# Altium schematic sheet [2] - Header and I2C.SchDoc
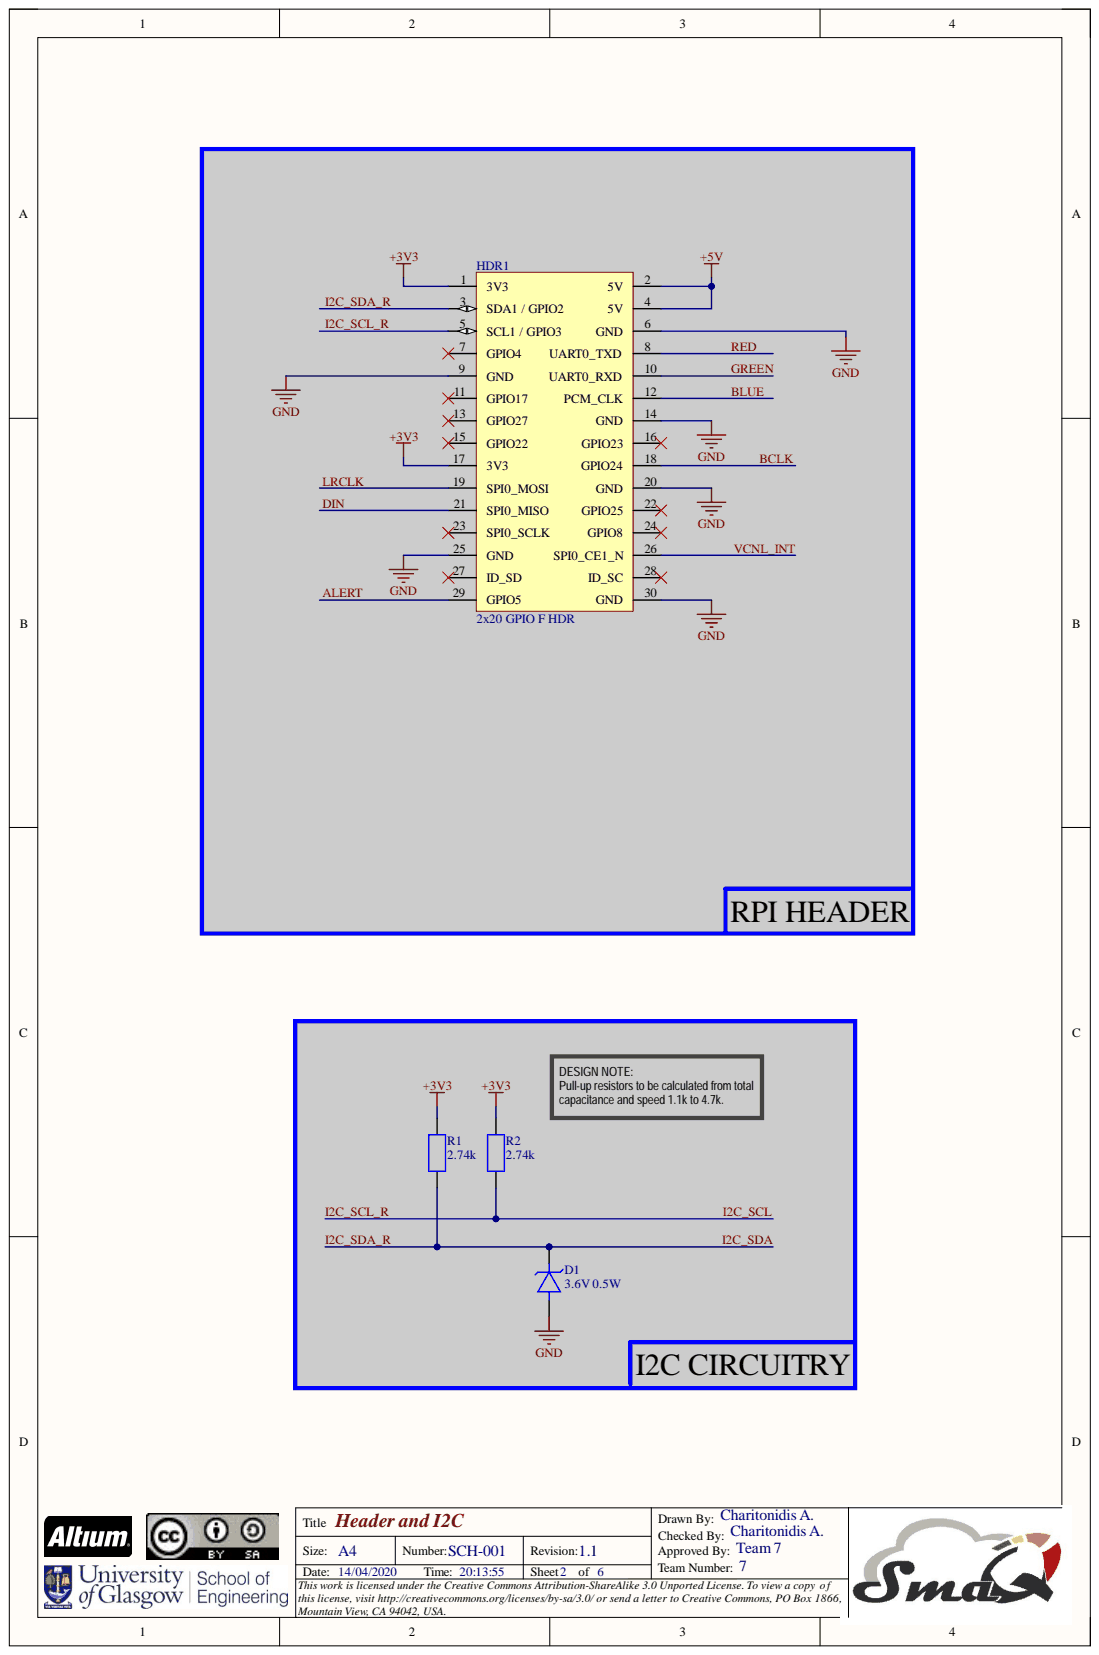
[diagram p0: [2] - Header and I2C.SchDoc]

## Parts
D1  3.6V 0.5W  Zener Voltage: 3.6V  [SOD-123]  (no BOM)
HDR1  2x20 GPIO F HDR  [2x20_Pi_Header]  (no BOM)
R1  2.74k  [R0805 (2012M)]  (no BOM)
R2  2.74k  [R0805 (2012M)]  (no BOM)

## Nets
+3V3: HDR1.1(3V3) HDR1.17(3V3) R1.2 R2.2
+5V: HDR1.2(5V) HDR1.4(5V)
GND: D1.1 HDR1.6(GND) HDR1.9(GND) HDR1.14(GND) HDR1.20(GND) HDR1.25(GND) HDR1.30(GND)
BCLK: HDR1.18(GPIO24)
BLUE: HDR1.12(PCM_CLK)
DIN: HDR1.21(SPI0_MISO)
GREEN: HDR1.10(UART0_RXD)
I2C_SCL: HDR1.5(SCL1_/_GPIO3) R2.1
I2C_SDA: D1.2 HDR1.3(SDA1_/_GPIO2) R1.1
LRCLK: HDR1.19(SPI0_MOSI)
RED: HDR1.8(UART0_TXD)
ALERT:
VCNL_INT:

Not connected: HDR1 7(GPIO4) 11(GPIO17) 13(GPIO27) 15(GPIO22) 16(GPIO23) 22(GPIO25) 23(SPI0_SCLK) 24(GPIO8) 26(SPI0_CE1_N) 27(ID_SD) 28(ID_SC) 29(GPIO5)

## Library data of the parts
D1 = BZT52B3V6-E3-08: Diode Zener Single 3.6V 2% 500mW 2-Pin SOD-123 T/R; Manufacturer 1: Vishay; Category 1: Zener Diodes; Impedance: 80R; Max Power Dissipation: 410mW; Max Reverse Leakage Current: 100nA; Zener Current: 100mA; Case/Package: SOD-123-2; ComponentLink1Description: https://datasheet.ciiva.com/24095/bzt52-106265-24095710.pdf?src-supplier=Mouser; ComponentLink1URL: https://datasheet.ciiva.com/24095/bzt52-106265-24095710.pdf?src-supplier=Mouser; Description 1: Diode Zener Single 3.6V 2% 500mW 2-Pin SOD-123 T/R; Element Configuration: Single; Manufacturer Part Number 1: BZT52B3V6-E3-08; Max Operating Temperature: 150°C; Min Operating Temperature: -55°C; Mount: Surface Mount; Number of Pins: 2; Package Quantity: 3000; Packaging: Tape and Reel; Power Dissipation: 500mW; RoHS: Yes; Stock 1: 29413; Supplier 1: Mouser; Supplier Part Number 1: 78-BZT52B3V6-E3-08; Tolerance: 2%; Voltage Tolerance: 2%; Weight: 0.000363oz; Library Name: Parts_Lib_SMAQ.SchLib
HDR1 = 2X20 Female Header: GPIO FEMALE HEADER RASPBERRY PI; Manufacturer 1: Adafruit Industries; Manufacturer Part Number 1: 2222; ComponentLink1Description: https://cdn-shop.adafruit.com/product-files/2222/00587.pdf?src-supplier=Digi-Key; ComponentLink1URL: https://cdn-shop.adafruit.com/product-files/2222/00587.pdf?src-supplier=Digi-Key; Description 1: GPIO FEMALE HEADER RASPBERRY PI; RoHS: No; Stock 1: 1767; Supplier 1: Mouser; Supplier Part Number 1: 485-2222; Library Name: Parts_Lib_SMAQ.SchLib
R1 R2 = ERA-6AEB2741V: Surface Mount Chip Resistor, Thin Film, AEC-Q200 ERA Series, 2.74 kohm, 125 mW, 0.1%, 100 V; Manufacturer 1: Panasonic; Category 1: Chip SMD Resistors; Max Power Dissipation: 125mW; Resistance: 2.74kR; Temperature Coefficient: 25ppm/°C; Voltage Rating: 100V; Case Code (Imperial): 0805; Case Code (Metric): 2012; Case/Package: 0805; ComponentLink1Description: https://datasheet.ciiva.com/11812/0900766b80eb1d8c-11812023.pdf?src-supplier=RSComponents; ComponentLink1URL: https://datasheet.ciiva.com/11812/0900766b80eb1d8c-11812023.pdf?src-supplier=RSComponents; ComponentLink2Description: https://datasheet.ciiva.com/41450/001181270-da-01-en-widerstand-era-0805-2k74-0-1-5000st-41450431.pdf?src-supplier=Conrad; ComponentLink2URL: https://datasheet.ciiva.com/41450/001181270-da-01-en-widerstand-era-0805-2k74-0-1-5000st-41450431.pdf?src-supplier=Conrad; ComponentLink3Description: https://datasheet.ciiva.com/24025/aoa0000ce26-197354-24025606.pdf?src-supplier=Mouser; ComponentLink3URL: https://datasheet.ciiva.com/24025/aoa0000ce26-197354-24025606.pdf?src-supplier=Mouser; ComponentLink4Description: https://datasheet.ciiva.com/7763/485442-7763559.pdf?src-supplier=Element14; ComponentLink4URL: https://datasheet.ciiva.com/7763/485442-7763559.pdf?src-supplier=Element14; ComponentLink5Description: https://datasheet.ciiva.com/7763/485442-7763560.pdf?src-supplier=Element14; ComponentLink5URL: https://datasheet.ciiva.com/7763/485442-7763560.pdf?src-supplier=Element14; ComponentLink6Description: https://datasheet.ciiva.com/6129/485442-6129482.pdf?src-supplier=Farnell; ComponentLink6URL: https://datasheet.ciiva.com/6129/485442-6129482.pdf?src-supplier=Farnell; ComponentLink7Description: https://datasheet.ciiva.com/6129/485442-6129483.pdf?src-supplier=Farnell; ComponentLink7URL: https://datasheet.ciiva.com/6129/485442-6129483.pdf?src-supplier=Farnell; ComponentLink8Description: https://datasheet.ciiva.com/6129/485442-6129478.pdf?src-supplier=Farnell; ComponentLink8URL: https://datasheet.ciiva.com/6129/485442-6129478.pdf?src-supplier=Farnell; ComponentLink9Description: https://datasheet.ciiva.com/9196/aoa0000ce26-9196845.pdf?src-supplier=Avnet; ComponentLink9URL: https://datasheet.ciiva.com/9196/aoa0000ce26-9196845.pdf?src-supplier=Avnet; Composition: Metal Film,Thin Film; Contact Plating: Tin; Depth: 1.25mm; Description 1: Surface Mount Chip Resistor, Thin Film, AEC-Q200 ERA Series, 2.74 kohm, 125 mW, 0.1%, 100 V; Features: Automotive AEC-Q200; Height: 0.5mm; Lead Free: Lead Free; Length: 2mm; Manufacturer Part Number 1: ERA-6AEB2741V; Max Operating Temperature: 155°C; Military Standard: Not; Min Operating Temperature: -55°C; Mount: Surface Mount; Number of Pins: 2; Number of Terminations: 2; Package Quantity: 1; Packaging: Tape and Reel; Power Rating: 125mW; Pricing 1: 5000=0.02100 (GBP); Radiation Hardening: No; RoHS: Yes; Stock 1: 20000; Supplier 1: Farnell; Supplier Part Number 1: 1810978; Termination: Solder; Tolerance: 0.1%; Voltage Rating (DC): 100V; Width: 1.25mm; Library Name: Parts_Lib_SMAQ.SchLib
